# Altium schematic sheet Sheet1.SchDoc
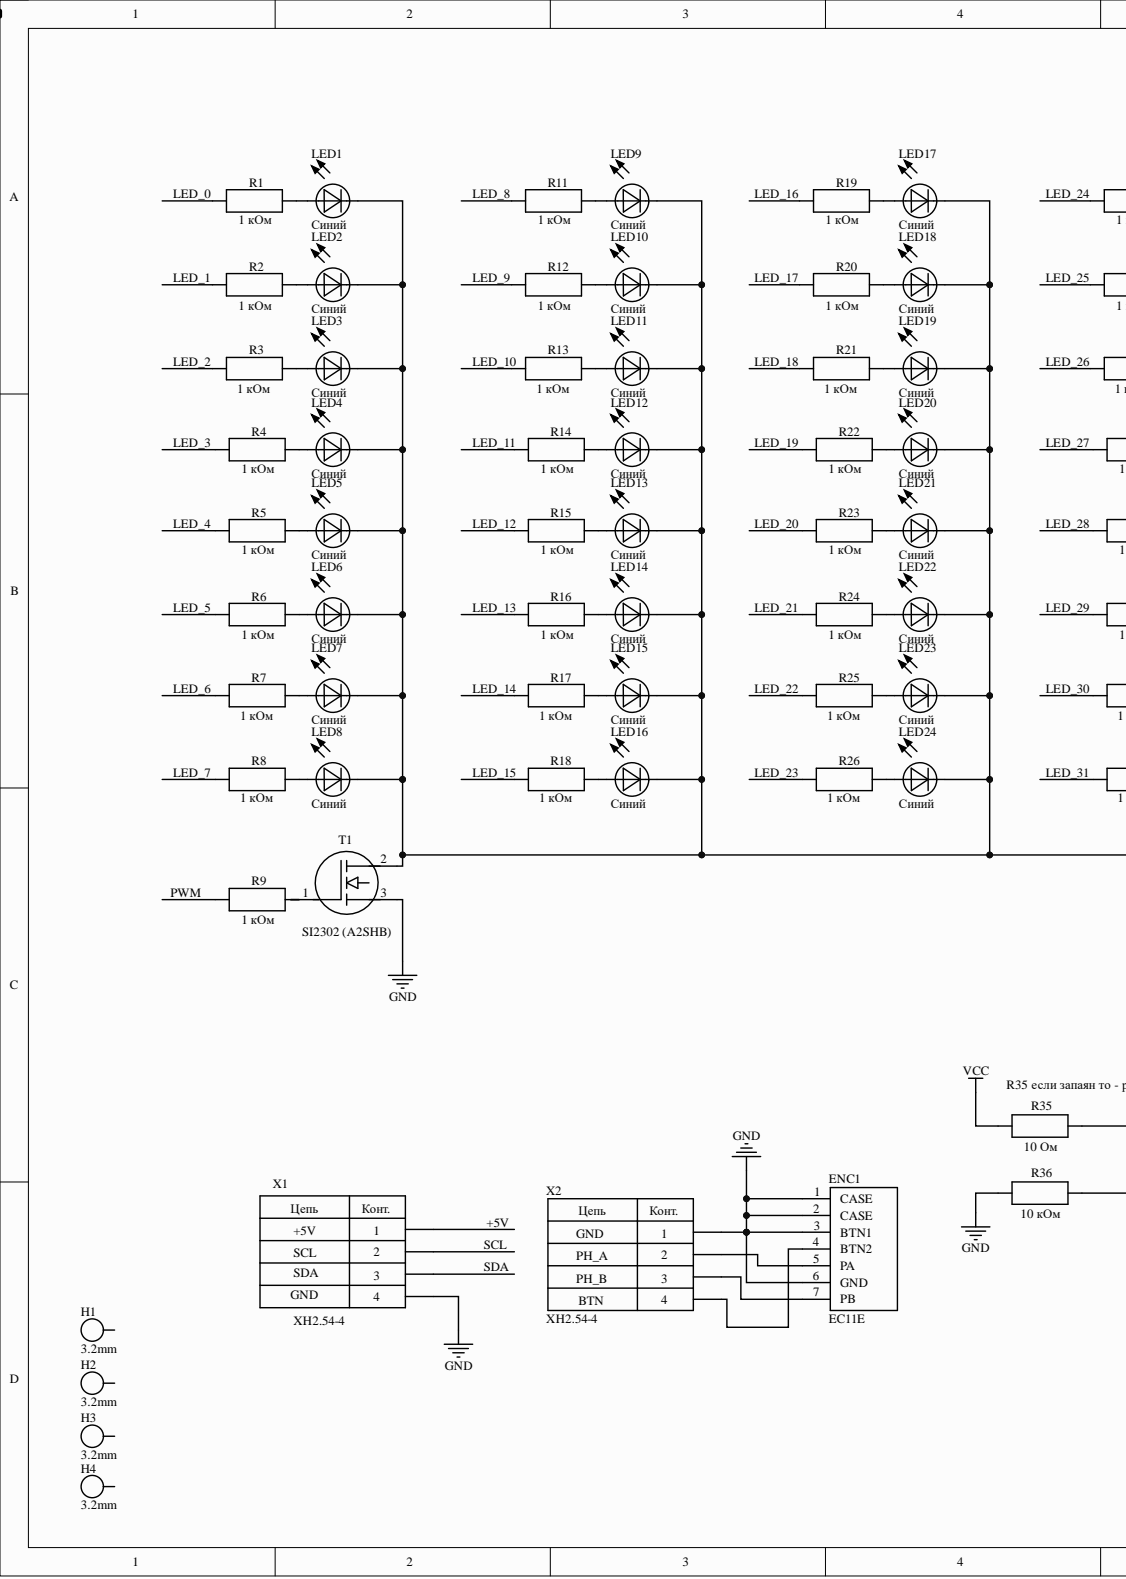
[diagram p0: Sheet1.SchDoc, left]
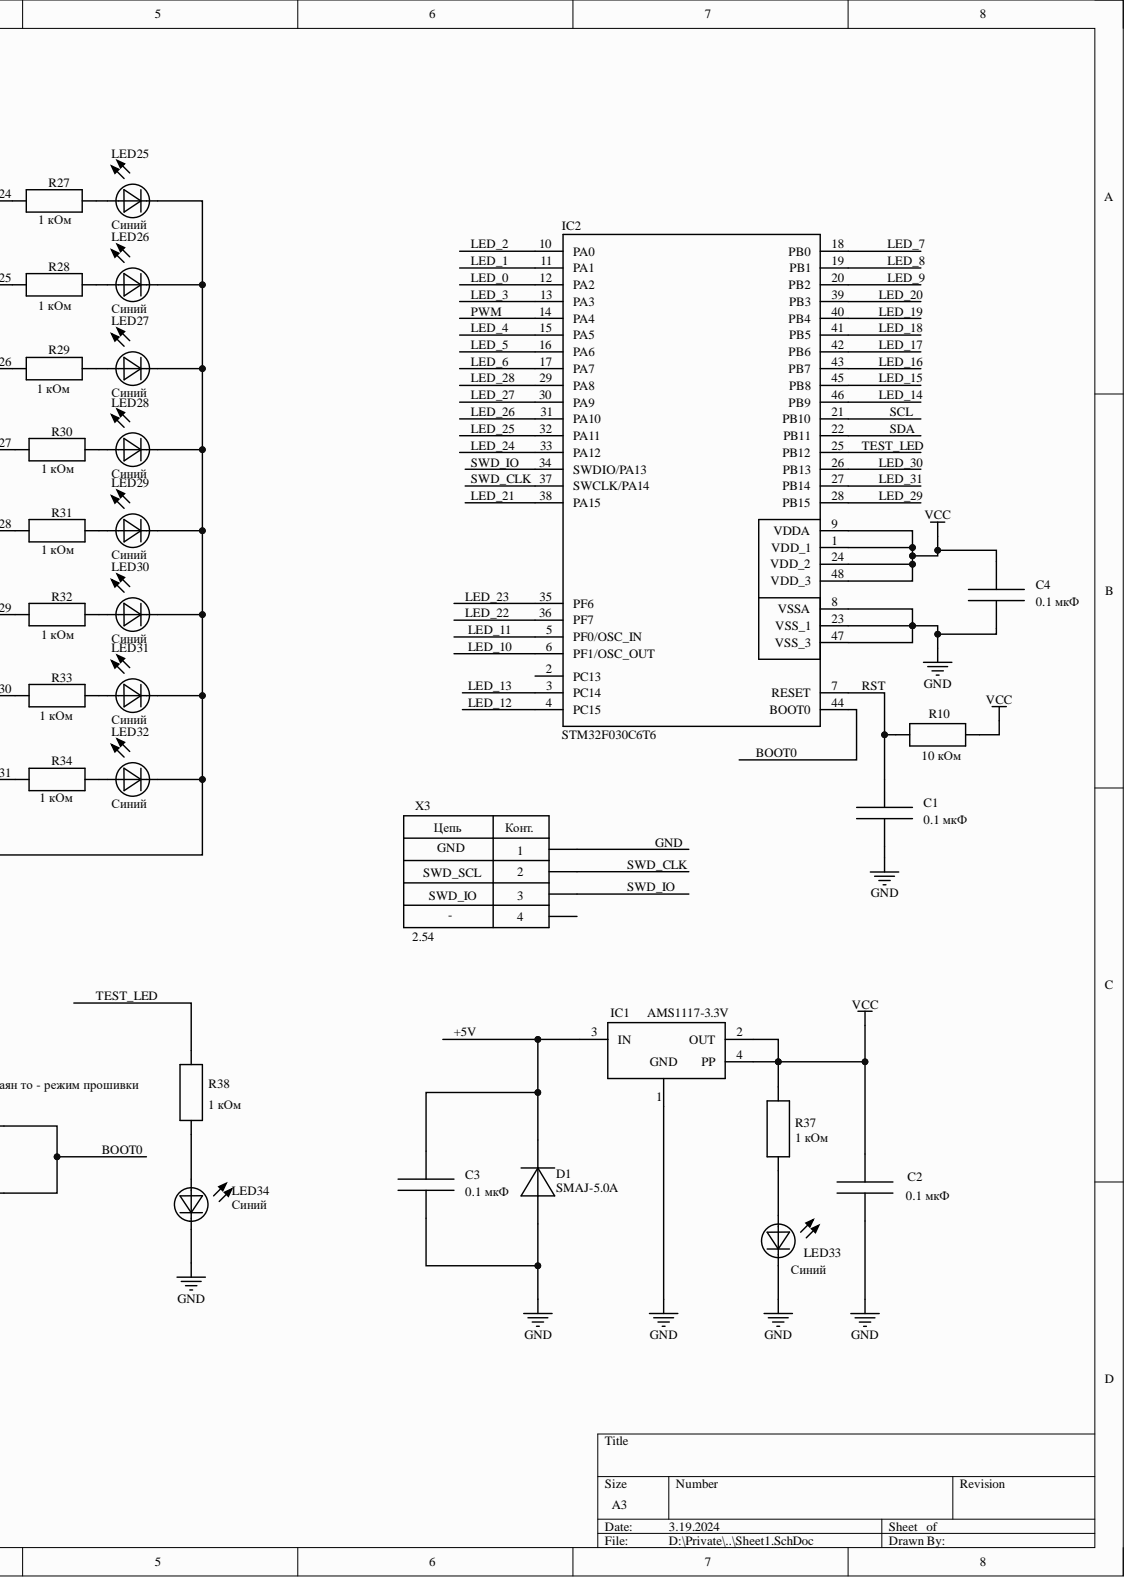
[diagram p1: Sheet1.SchDoc, right]

## Parts
C1  0.1 мкФ  [Конденсатор SMD Case 0805]
C2  0.1 мкФ  [Конденсатор SMD Case 1206]
C3  0.1 мкФ  [Конденсатор SMD Case 0805]
C4  0.1 мкФ  [Конденсатор SMD Case 0805]
D1  SMAJ-5.0A  [Диод SS14]
ENC1  EC11E  [Encoder]
H1  3.2mm  [Отверстие 3,2]
H2  3.2mm  [Отверстие 3,2]
H3  3.2mm  [Отверстие 3,2]
H4  3.2mm  [Отверстие 3,2]
IC1  AMS1117-3.3V  [SOT-223]
IC2  STM32F030С6T6  [TQFP-48]
LED1  Синий  [Светодиод  3x2 mm]
LED2  Синий  [Светодиод  3x2 mm]
LED3  Синий  [Светодиод  3x2 mm]
LED4  Синий  [Светодиод  3x2 mm]
LED5  Синий  [Светодиод  3x2 mm]
LED6  Синий  [Светодиод  3x2 mm]
LED7  Синий  [Светодиод  3x2 mm]
LED8  Синий  [Светодиод  3x2 mm]
LED9  Синий  [Светодиод  3x2 mm]
LED10  Синий  [Светодиод  3x2 mm]
LED11  Синий  [Светодиод  3x2 mm]
LED12  Синий  [Светодиод  3x2 mm]
LED13  Синий  [Светодиод  3x2 mm]
LED14  Синий  [Светодиод  3x2 mm]
LED15  Синий  [Светодиод  3x2 mm]
LED16  Синий  [Светодиод  3x2 mm]
LED17  Синий  [Светодиод  3x2 mm]
LED18  Синий  [Светодиод  3x2 mm]
LED19  Синий  [Светодиод  3x2 mm]
LED20  Синий  [Светодиод  3x2 mm]
LED21  Синий  [Светодиод  3x2 mm]
LED22  Синий  [Светодиод  3x2 mm]
LED23  Синий  [Светодиод  3x2 mm]
LED24  Синий  [Светодиод  3x2 mm]
LED25  Синий  [Светодиод  3x2 mm]
LED26  Синий  [Светодиод  3x2 mm]
LED27  Синий  [Светодиод  3x2 mm]
LED28  Синий  [Светодиод  3x2 mm]
LED29  Синий  [Светодиод  3x2 mm]
LED30  Синий  [Светодиод  3x2 mm]
LED31  Синий  [Светодиод  3x2 mm]
LED32  Синий  [Светодиод  3x2 mm]
LED33  Синий  [SMD 1206 Светодиод ВАЙТ]
LED34  Синий  [SMD 1206 Светодиод ВАЙТ]
R1  1 кОм  [SMD 0805 Резистор]
R2  1 кОм  [SMD 0805 Резистор]
R3  1 кОм  [SMD 0805 Резистор]
R4  1 кОм  [SMD 0805 Резистор]
R5  1 кОм  [SMD 0805 Резистор]
R6  1 кОм  [SMD 0805 Резистор]
R7  1 кОм  [SMD 0805 Резистор]
R8  1 кОм  [SMD 0805 Резистор]
R9  1 кОм  [SMD 0805 Резистор]
R10  10 кОм  [SMD 0805 Резистор]
R11  1 кОм  [SMD 0805 Резистор]
R12  1 кОм  [SMD 0805 Резистор]
R13  1 кОм  [SMD 0805 Резистор]
R14  1 кОм  [SMD 0805 Резистор]
R15  1 кОм  [SMD 0805 Резистор]
R16  1 кОм  [SMD 0805 Резистор]
R17  1 кОм  [SMD 0805 Резистор]
R18  1 кОм  [SMD 0805 Резистор]
R19  1 кОм  [SMD 0805 Резистор]
R20  1 кОм  [SMD 0805 Резистор]
R21  1 кОм  [SMD 0805 Резистор]
R22  1 кОм  [SMD 0805 Резистор]
R23  1 кОм  [SMD 0805 Резистор]
R24  1 кОм  [SMD 0805 Резистор]
R25  1 кОм  [SMD 0805 Резистор]
R26  1 кОм  [SMD 0805 Резистор]
R27  1 кОм  [SMD 0805 Резистор]
R28  1 кОм  [SMD 0805 Резистор]
R29  1 кОм  [SMD 0805 Резистор]
R30  1 кОм  [SMD 0805 Резистор]
R31  1 кОм  [SMD 0805 Резистор]
R32  1 кОм  [SMD 0805 Резистор]
R33  1 кОм  [SMD 0805 Резистор]
R34  1 кОм  [SMD 0805 Резистор]
R35  10 Ом  [SMD 0805 Резистор]
R36  10 кОм  [SMD 0805 Резистор]
R37  1 кОм  [SMD 0805 Резистор]
R38  1 кОм  [SMD 0805 Резистор]
T1  SI2302 (A2SHB)  [SMD SOT-23 Транзистор 3 pin]
X1  XH2.54-4  [(1x4) PIN XH 2.54 HEADR]
X2  XH2.54-4  [(1x4) PIN XH 2.54 HEADR]
X3  2.54  [(1x4)PIN 2.54 HEADR]

## Nets
VCC: C2.1 C4.1 IC1.2(OUT) IC1.4(PP) IC2.1(VDD_1) IC2.9(VDDA) IC2.24(VDD_2) IC2.48(VDD_3) R10.2 R35.1 R37.2
+5V: C3.1 D1.2 IC1.3(IN) X1.1
BOOT0: IC2.44(BOOT0) R35.2 R36.2
GND: C1.2 C2.2 C3.2 C4.2 D1.1 ENC1.1(CASE) ENC1.2(CASE) ENC1.3(BTN1) ENC1.6(GND) IC1.1 IC2.8(VSSA) IC2.23(VSS_1) IC2.47(VSS_3) LED33.2 LED34.2 R36.1 T1.3 X1.4 X2.1 X3.1
LED_0: IC2.12(PA2) R1.1
LED_1: IC2.11(PA1) R2.1
LED_2: IC2.10(PA0) R3.1
LED_3: IC2.13(PA3) R4.1
LED_4: IC2.15(PA5) R5.1
LED_5: IC2.16(PA6) R6.1
LED_6: IC2.17(PA7) R7.1
LED_7: IC2.18(PB0) R8.1
LED_8: IC2.19(PB1) R11.1
LED_9: IC2.20(PB2) R12.1
LED_10: IC2.6(PF1/OSC_OUT) R13.1
LED_11: IC2.5(PF0/OSC_IN) R14.1
LED_12: IC2.4(PC15) R15.1
LED_13: IC2.3(PC14) R16.1
LED_14: IC2.46(PB9) R17.1
LED_15: IC2.45(PB8) R18.1
LED_16: IC2.43(PB7) R19.1
LED_17: IC2.42(PB6) R20.1
LED_18: IC2.41(PB5) R21.1
LED_19: IC2.40(PB4) R22.1
LED_20: IC2.39(PB3) R23.1
LED_21: IC2.38(PA15) R24.1
LED_22: IC2.36(PF7) R25.1
LED_23: IC2.35(PF6) R26.1
LED_24: IC2.33(PA12) R27.1
LED_25: IC2.32(PA11) R28.1
LED_26: IC2.31(PA10) R29.1
LED_27: IC2.30(PA9) R30.1
LED_28: IC2.29(PA8) R31.1
LED_29: IC2.28(PB15) R32.1
LED_30: IC2.26(PB13) R33.1
LED_31: IC2.27(PB14) R34.1
PWM: IC2.14(PA4) R9.1
RST: C1.1 IC2.7(RESET) R10.1
SCL: IC2.21(PB10) X1.2
SDA: IC2.22(PB11) X1.3
SWD_CLK: IC2.37(SWCLK/PA14) X3.2
SWD_IO: IC2.34(SWDIO/PA13) X3.3
TEST_LED: IC2.25(PB12) R38.2
NetENC1_4: ENC1.4(BTN2) X2.4
NetENC1_5: ENC1.5(PA) X2.2
NetENC1_7: ENC1.7(PB) X2.3
NetLED1_1: LED1.1 R1.2
NetLED1_2: LED1.2 LED2.2 LED3.2 LED4.2 LED5.2 LED6.2 LED7.2 LED8.2 LED9.2 LED10.2 LED11.2 LED12.2 LED13.2 LED14.2 LED15.2 LED16.2 LED17.2 LED18.2 LED19.2 LED20.2 LED21.2 LED22.2 LED23.2 LED24.2 LED25.2 LED26.2 LED27.2 LED28.2 LED29.2 LED30.2 LED31.2 LED32.2 T1.2
NetLED2_1: LED2.1 R2.2
NetLED3_1: LED3.1 R3.2
NetLED4_1: LED4.1 R4.2
NetLED5_1: LED5.1 R5.2
NetLED6_1: LED6.1 R6.2
NetLED7_1: LED7.1 R7.2
NetLED8_1: LED8.1 R8.2
NetLED9_1: LED9.1 R11.2
NetLED10_1: LED10.1 R12.2
NetLED11_1: LED11.1 R13.2
NetLED12_1: LED12.1 R14.2
NetLED13_1: LED13.1 R15.2
NetLED14_1: LED14.1 R16.2
NetLED15_1: LED15.1 R17.2
NetLED16_1: LED16.1 R18.2
NetLED17_1: LED17.1 R19.2
NetLED18_1: LED18.1 R20.2
NetLED19_1: LED19.1 R21.2
NetLED20_1: LED20.1 R22.2
NetLED21_1: LED21.1 R23.2
NetLED22_1: LED22.1 R24.2
NetLED23_1: LED23.1 R25.2
NetLED24_1: LED24.1 R26.2
NetLED25_1: LED25.1 R27.2
NetLED26_1: LED26.1 R28.2
NetLED27_1: LED27.1 R29.2
NetLED28_1: LED28.1 R30.2
NetLED29_1: LED29.1 R31.2
NetLED30_1: LED30.1 R32.2
NetLED31_1: LED31.1 R33.2
NetLED32_1: LED32.1 R34.2
NetLED33_1: LED33.1 R37.1
NetLED34_1: LED34.1 R38.1
NetR9_2: R9.2 T1.1

Not connected: H1 1; H2 1; H3 1; H4 1; IC2 2(PC13); X3 4

## Library data of the parts
C1-C4 = Конденсатор: Component Kind: Standard; Library Name: S83.IntLib; Value: 0.1 мкФ
  C1 C3 C4: Case: SMD 0805
  C2: Case: SMD 1206
D1 = Диод: Case: DO-214AC; Component Kind: Standard; Library Name: S83.IntLib; Value: SMAJ-5.0A
ENC1 = Encoder_01: Case: -; Component Kind: Standard; Library Name: S83.IntLib; Value: EC11E
H1-H4 = Отверстие монтажное: Case: Отверстие; Component Kind: Standard; Library Name: S83.IntLib; Value: 3.2mm
IC1 = AMS1117: Case: SOT-223; Component Kind: Standard; Library Name: S83_IC.IntLib; Value: AMS1117-3.3V
IC2 = STM32F030: Component Kind: Standard; Library Name: S83_IC.IntLib; Value: STM32F030С6T6
LED1-LED34 = Светодиод: Component Kind: Standard; Library Name: S83.IntLib; Value: Синий
  LED1-LED32: Case: 3x2x4
  LED33 LED34: Case: SMD 1206
R1-R38 = Резистор: Case: SMD 0805; Component Kind: Standard; Library Name: S83.IntLib
  R1-R9 R11-R34 R37 R38: Value: 1 кОм
  R10 R36: Value: 10 кОм
  R35: Value: 10 Ом
T1 = Транзистор MOSFET N-конал (как n-p-n): Case: SOT-23; Component Kind: Standard; Library Name: S83.IntLib; Value: SI2302 (A2SHB)
X1-X3 = (1x4) pin XH 2.54 Header: Component Kind: Standard; Library Name: S83_connectors.IntLib
  X1 X2: Case: XH2.54-4; Value: XH2.54-4
  X3: Value: 2.54
